# Altium schematic sheet 5-DisplayPort & Ethernet.SchDoc
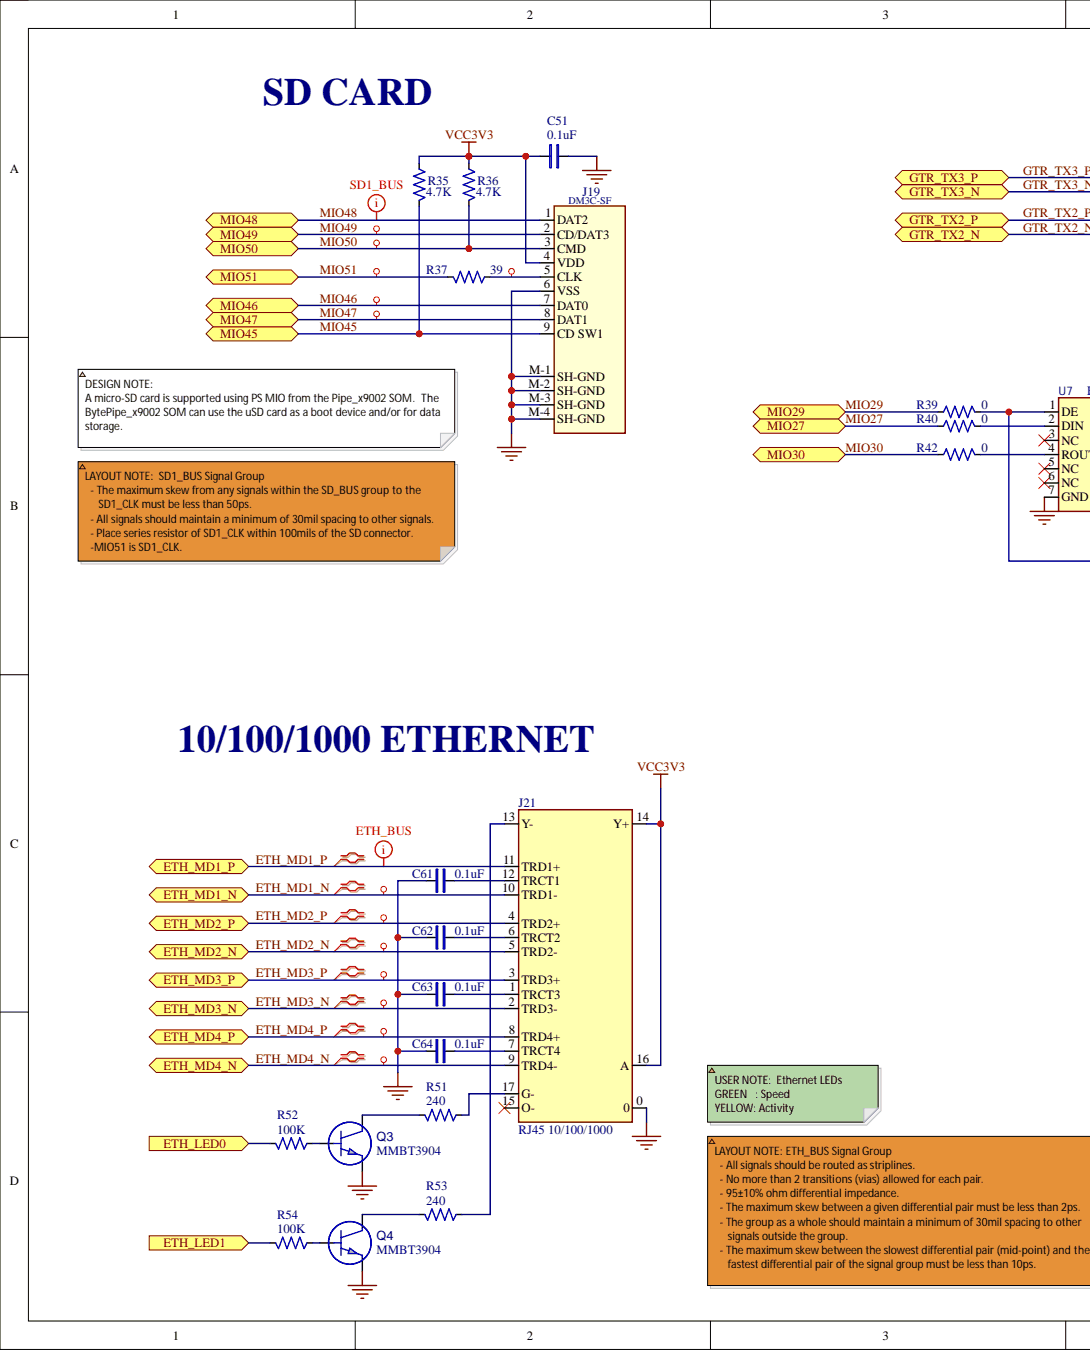
[diagram p0: 5-DisplayPort & Ethernet.SchDoc, left]
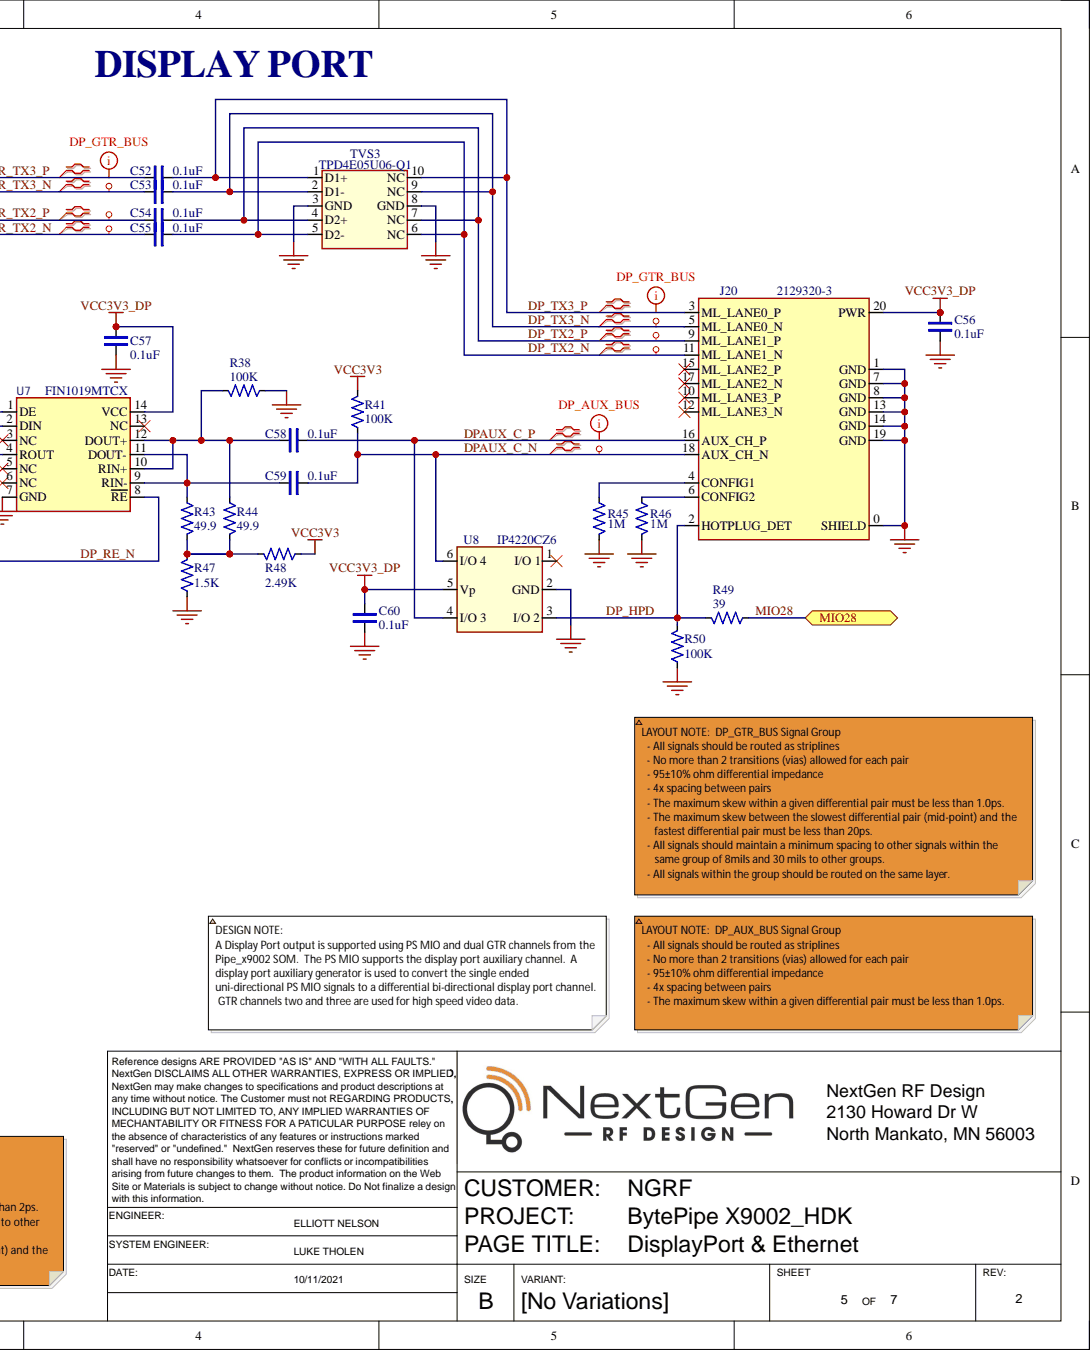
[diagram p1: 5-DisplayPort & Ethernet.SchDoc, right]

## Parts
C51  value 0.1uF  [SM/0402/CAP]  (hidden comment: CAP_CERAMIC_0402)
C52  value 0.1uF  [SM/0402/CAP]  (hidden comment: CAP_CERAMIC_0402)
C53  value 0.1uF  [SM/0402/CAP]  (hidden comment: CAP_CERAMIC_0402)
C54  value 0.1uF  [SM/0402/CAP]  (hidden comment: CAP_CERAMIC_0402)
C55  value 0.1uF  [SM/0402/CAP]  (hidden comment: CAP_CERAMIC_0402)
C56  value 0.1uF  [SM/0402/CAP]  (hidden comment: CAP_CERAMIC_0402)
C57  value 0.1uF  [SM/0402/CAP]  (hidden comment: CAP_CERAMIC_0402)
C58  value 0.1uF  [SM/0402/CAP]  (hidden comment: CAP_CERAMIC_0402)
C59  value 0.1uF  [SM/0402/CAP]  (hidden comment: CAP_CERAMIC_0402)
C60  value 0.1uF  [SM/0402/CAP]  (hidden comment: CAP_CERAMIC_0402)
C61  value 0.1uF  [SM/0402/CAP]  (hidden comment: CAP_CERAMIC_0402)
C62  value 0.1uF  [SM/0402/CAP]  (hidden comment: CAP_CERAMIC_0402)
C63  value 0.1uF  [SM/0402/CAP]  (hidden comment: CAP_CERAMIC_0402)
C64  value 0.1uF  [SM/0402/CAP]  (hidden comment: CAP_CERAMIC_0402)
J19  value DM3C-SF  OEM#: DM3C-SF  [SM/CON/MICRO_SD-CARD/HOLDER]  (hidden comment: CONN MICRO SD CARD HINGED TYPE)
J20  value 2129320-3  OEM#: 2129320-3  [CON/TE/2129320-3]  (hidden comment: CON_TE_2129320-3)
J21  value RJ45 10/100/1000  [CON/RJ45/L829-1J1T-43]  (hidden comment: CON_RJ45_MagJack_L829-1J1T-43)
Q3  value MMBT3904  [SM/SOT23_A]  (hidden comment: TRANSISTOR_NPN_SOT23)
Q4  value MMBT3904  [SM/SOT23_A]  (hidden comment: TRANSISTOR_NPN_SOT23)
R35  value 4.7K  [SM/0402/RES]  (hidden comment: RES_0402_A)
R36  value 4.7K  [SM/0402/RES]  (hidden comment: RES_0402_A)
R37  value 39  [SM/0402/RES]  (hidden comment: RES_0402_A)
R38  value 100K  [SM/0402/RES]  (hidden comment: RES_0402_A)
R39  value 0  [SM/0402/RES]  (hidden comment: RES_0402_A)
R40  value 0  [SM/0402/RES]  (hidden comment: RES_0402_A)
R41  value 100K  [SM/0402/RES]  (hidden comment: RES_0402_A)
R42  value 0  [SM/0402/RES]  (hidden comment: RES_0402_A)
R43  value 49.9  [SM/0402/RES]  (hidden comment: RES_0402_A)
R44  value 49.9  [SM/0402/RES]  (hidden comment: RES_0402_A)
R45  value 1M  [SM/0402/RES]  (hidden comment: RES_0402_A)
R46  value 1M  [SM/0402/RES]  (hidden comment: RES_0402_A)
R47  value 1.5K  [SM/0402/RES]  (hidden comment: RES_0402_A)
R48  value 2.49K  [SM/0402/RES]  (hidden comment: RES_0402_A)
R49  value 39  [SM/0402/RES]  (hidden comment: RES_0402_A)
R50  value 100K  [SM/0402/RES]  (hidden comment: RES_0402_A)
R51  value 240  [SM/0402/RES]  (hidden comment: RES_0402_A)
R52  value 100K  [SM/0402/RES]  (hidden comment: RES_0402_A)
R53  value 240  [SM/0402/RES]  (hidden comment: RES_0402_A)
R54  value 100K  [SM/0402/RES]  (hidden comment: RES_0402_A)
TVS3  value TPD4E05U06-Q1  OEM#: TPD4E05U06-Q1  [SM/DFN10/2.5X1MM_A]  (hidden comment: TVS_TPD4E05U06_2.5mmX1mm)
U7  value FIN1019MTCX  OEM#: FIN1019MTCX  [SM/TSSOP14_5X6.4MM_A]  (hidden comment: IC_FIN1019MTCX_TSSOP-14)
U8  value IP4220CZ6  [SM/TSOP-6_A]  (hidden comment: IC_IP4220CZ6_TSOP-6)

## Ports
ETH_LED0, ETH_LED1, ETH_MD1_N, ETH_MD1_P, ETH_MD2_N, ETH_MD2_P, ETH_MD3_N, ETH_MD3_P, ETH_MD4_N, ETH_MD4_P, GTR_TX2_N, GTR_TX2_P, GTR_TX3_N, GTR_TX3_P, MIO27, MIO28, MIO29, MIO30, MIO45, MIO46, MIO47, MIO48, MIO49, MIO50, MIO51

## Nets
GND: C51.2 C56.2 C57.2 C60.2 C61.1 C62.1 C63.1 C64.1 J19.6(VSS) J19.M-1(SH-GND) J19.M-2(SH-GND) J19.M-3(SH-GND) J19.M-4(SH-GND) J20.0(SHIELD) J20.1(GND) J20.7(GND) J20.8(GND) J20.13(GND) J20.14(GND) J20.19(GND) J21.0 Q3.2 Q4.2 R38.1 R45.2 R46.2 R47.1 R50.1 TVS3.3(GND) TVS3.8(GND) U7.7(GND) U8.2(GND)
VCC3V3: C51.1 J19.4(VDD) J21.14(Y+) J21.16(A) R35.1 R36.1 R41.2 R48.1
VCC3V3_DP: C56.1 C57.1 C60.1 J20.20(PWR) U7.14(VCC) U8.5(Vp)
DP_HPD: J20.2(HOTPLUG_DET) R49.2 R50.2 U8.3(I/O_2)
DP_RE_N: R39.1 U7.1(DE) U7.8(RE)
DP_TX2_N: C55.2 J20.11(ML_LANE1_N) TVS3.5(D2-) TVS3.6(NC)
DP_TX2_P: C54.2 J20.9(ML_LANE1_P) TVS3.4(D2+) TVS3.7(NC)
DP_TX3_N: C53.2 J20.5(ML_LANE0_N) TVS3.2(D1-) TVS3.9(NC)
DP_TX3_P: C52.2 J20.3(ML_LANE0_P) TVS3.1(D1+) TVS3.10(NC)
DPAUX_C_N: C59.2 J20.18(AUX_CH_N) R41.1 U8.6(I/O_4)
DPAUX_C_P: C58.2 J20.16(AUX_CH_P) U8.4(I/O_3)
ETH_LED0: R52.2 ; port ETH_LED0
ETH_LED1: R54.2 ; port ETH_LED1
ETH_MD1_N: J21.10(TRD1-) ; port ETH_MD1_N
ETH_MD1_P: J21.11(TRD1+) ; port ETH_MD1_P
ETH_MD2_N: J21.5(TRD2-) ; port ETH_MD2_N
ETH_MD2_P: J21.4(TRD2+) ; port ETH_MD2_P
ETH_MD3_N: J21.2(TRD3-) ; port ETH_MD3_N
ETH_MD3_P: J21.3(TRD3+) ; port ETH_MD3_P
ETH_MD4_N: J21.9(TRD4-) ; port ETH_MD4_N
ETH_MD4_P: J21.8(TRD4+) ; port ETH_MD4_P
GTR_TX2_N: C55.1 ; port GTR_TX2_N
GTR_TX2_P: C54.1 ; port GTR_TX2_P
GTR_TX3_N: C53.1 ; port GTR_TX3_N
GTR_TX3_P: C52.1 ; port GTR_TX3_P
MIO27: R40.2 ; port MIO27
MIO28: R49.1 ; port MIO28
MIO29: R39.2 ; port MIO29
MIO30: R42.2 ; port MIO30
MIO45: J19.9(CD_SW1) R35.2 ; port MIO45
MIO46: J19.7(DAT0) ; port MIO46
MIO47: J19.8(DAT1) ; port MIO47
MIO48: J19.1(DAT2) ; port MIO48
MIO49: J19.2(CD/DAT3) ; port MIO49
MIO50: J19.3(CMD) R36.2 ; port MIO50
MIO51: R37.2 ; port MIO51
NetC58_1: C58.1 R38.2 R44.1 U7.10(RIN+) U7.12(DOUT+)
NetC59_1: C59.1 R43.1 U7.9(RIN-) U7.11(DOUT-)
NetC61_2: C61.2 J21.12(TRCT1)
NetC62_2: C62.2 J21.6(TRCT2)
NetC63_2: C63.2 J21.1(TRCT3)
NetC64_2: C64.2 J21.7(TRCT4)
NetJ19_5: J19.5(CLK) R37.1
NetJ20_4: J20.4(CONFIG1) R45.1
NetJ20_6: J20.6(CONFIG2) R46.1
NetJ21_13: J21.13(Y-) R53.2
NetJ21_17: J21.17(G-) R51.2
NetQ3_1: Q3.1 R52.1
NetQ3_3: Q3.3 R51.1
NetQ4_1: Q4.1 R54.1
NetQ4_3: Q4.3 R53.1
NetR40_1: R40.1 U7.2(DIN)
NetR42_1: R42.1 U7.4(ROUT)
NetR43_2: R43.2 R44.2 R47.2 R48.2

Not connected: J20 10(ML_LANE3_P) 12(ML_LANE3_N) 15(ML_LANE2_P) 17(ML_LANE2_N); J21 15(O-); U7 3(NC) 5(NC) 6(NC) 13(NC); U8 1(I/O_1)

## Library data of the parts
C51-C64 = CAP_CERAMIC_0402: CAP CER 0.1UF 25V X7R 0402; OEM NAME: Samsung; OEM#: CL05B104KA5NNNC; TOL: ±10%; Component Kind: Standard; VOLT: 25V; Library Name: NextGenRF_Symbols_SMT.SchLib
J19 = CON_HIROSE_MICRO-SD_HINGED: 10 (8 + 2) Position Card Connector Secure Digital - microSD™ Surface Mount, Right Angle Gold; OEM NAME: Hirose Electric Co Ltd; Component Kind: Standard; Library Name: NextGenRF_Symbols_SMT.SchLib
J20 = CON_TE_2129320-3: DisplayPort, Mini  Receptacle Connector 20 Position Through Hole, Right Angle..; OEM NAME: TE; Component Kind: Standard; Library Name: NextGenRF_Symbols_TH.SchLib
J21 = CON_RJ45_MagJack_L829-1J1T-43: 1 Port RJ45 Magjack Connector Through Hole 10/100/1000 Base-T, AutoMDIX; OEM NAME: Bel Fuse Inc; Component Kind: Standard; OEM#: L829-1J1T-43; Library Name: NextGenRF_Symbols_TH.SchLib
Q3 Q4 = TRANSISTOR_NPN_SOT23: Bipolar (BJT) Transistor NPN 40V 200mA 300MHz 300mW Surface Mount SOT-23-3 (TO-236); OEM NAME: ON Semiconductor; OEM#: MMBT3904LT1G; Component Kind: Standard; Library Name: NextGenRF_Symbols_SMT.SchLib
R35 R36 = RES_0402_A: RES SMD 4.7K OHM 1% 1/10W 0402; OEM NAME: Panasonic; OEM#: ERJ-2RKF4701X; Component Kind: Standard; TOL: 1%; Library Name: NextGenRF_Symbols_SMT.SchLib
R37 R49 = RES_0402_A: RES SMD 39 OHM 1% 1/10W 0402; OEM NAME: Panasonic; OEM#: ERJ-2RKF39R0X; Component Kind: Standard; TOL: 1%; Library Name: NextGenRF_Symbols_SMT.SchLib
R38 R41 R50 R52 R54 = RES_0402_A: RES SMD 100K OHM 1% 1/10W 0402; OEM NAME: Panasonic; OEM#: ERJ-2RKF1003X; Component Kind: Standard; TOL: 1%; Library Name: NextGenRF_Symbols_SMT.SchLib
R39 R40 R42 = RES_0402_A: RES SMD 0 OHM JUMPER 1/10W 0402; OEM NAME: Panasonic; OEM#: ERJ-2GE0R00X; TOL: 1%; Component Kind: Standard; Library Name: NextGenRF_Symbols_SMT.SchLib
R43 R44 = RES_0402_A: RES 49.9 OHM 1% 1/10W 0402; OEM NAME: Panasonic; OEM#: ERJ-2RKF49R9X; Component Kind: Standard; TOL: ±1%; Library Name: NextGenRF_Symbols_SMT.SchLib
R45 R46 = RES_0402_A: RES SMD 1M OHM 1% 1/10W 0402; OEM NAME: Panasonic; OEM#: ERJ-2RKF1004X; Component Kind: Standard; TOL: ±1%; Library Name: NextGenRF_Symbols_SMT.SchLib
R47 = RES_0402_A: RES SMD 1.5K OHM 1% 1/10W 0402; OEM NAME: Panasonic; OEM#: ERJ-2RKF1501X; Component Kind: Standard; TOL: ±1%; Library Name: NextGenRF_Symbols_SMT.SchLib
R48 = RES_0402_A: RES SMD 2.49K OHM 1% 1/10W 0402; OEM NAME: Panasonic; OEM#: ERJ-2RKF2491X; Component Kind: Standard; TOL: ±1%; Library Name: NextGenRF_Symbols_SMT.SchLib
R51 R53 = RES_0402_A: RES SMD 240 OHM 1% 1/10W 0402; OEM NAME: Panasonic; OEM#: ERJ-2RKF2400X; Component Kind: Standard; TOL: 1%; Library Name: NextGenRF_Symbols_SMT.SchLib
TVS3 = TVS_TPD4E05U06_2.5mmX1mm: TVS DIODE 5.5V 14V 10SON; OEM NAME: TI; Component Kind: Standard; Library Name: NextGenRF_Symbols_SMT.SchLib
U7 = IC_FIN1019MTCX_TSSOP-14: 1/1 Transceiver Full LVDS 14-TSSOP; OEM NAME: ON Semiconductor; Component Kind: Standard; Library Name: NextGenRF_Symbols_SMT.SchLib
U8 = IC_IP4220CZ6_TSOP-6: Dual USB ESD Protection; OEM NAME: NXP; OEM#: IP4220CZ6,125; Component Kind: Standard; Library Name: NextGenRF_Symbols_SMT.SchLib
